# Altium schematic sheet ESP32-HWE-Dev-I2C.SchDoc(ESP32-HWE-Dev-I2C)
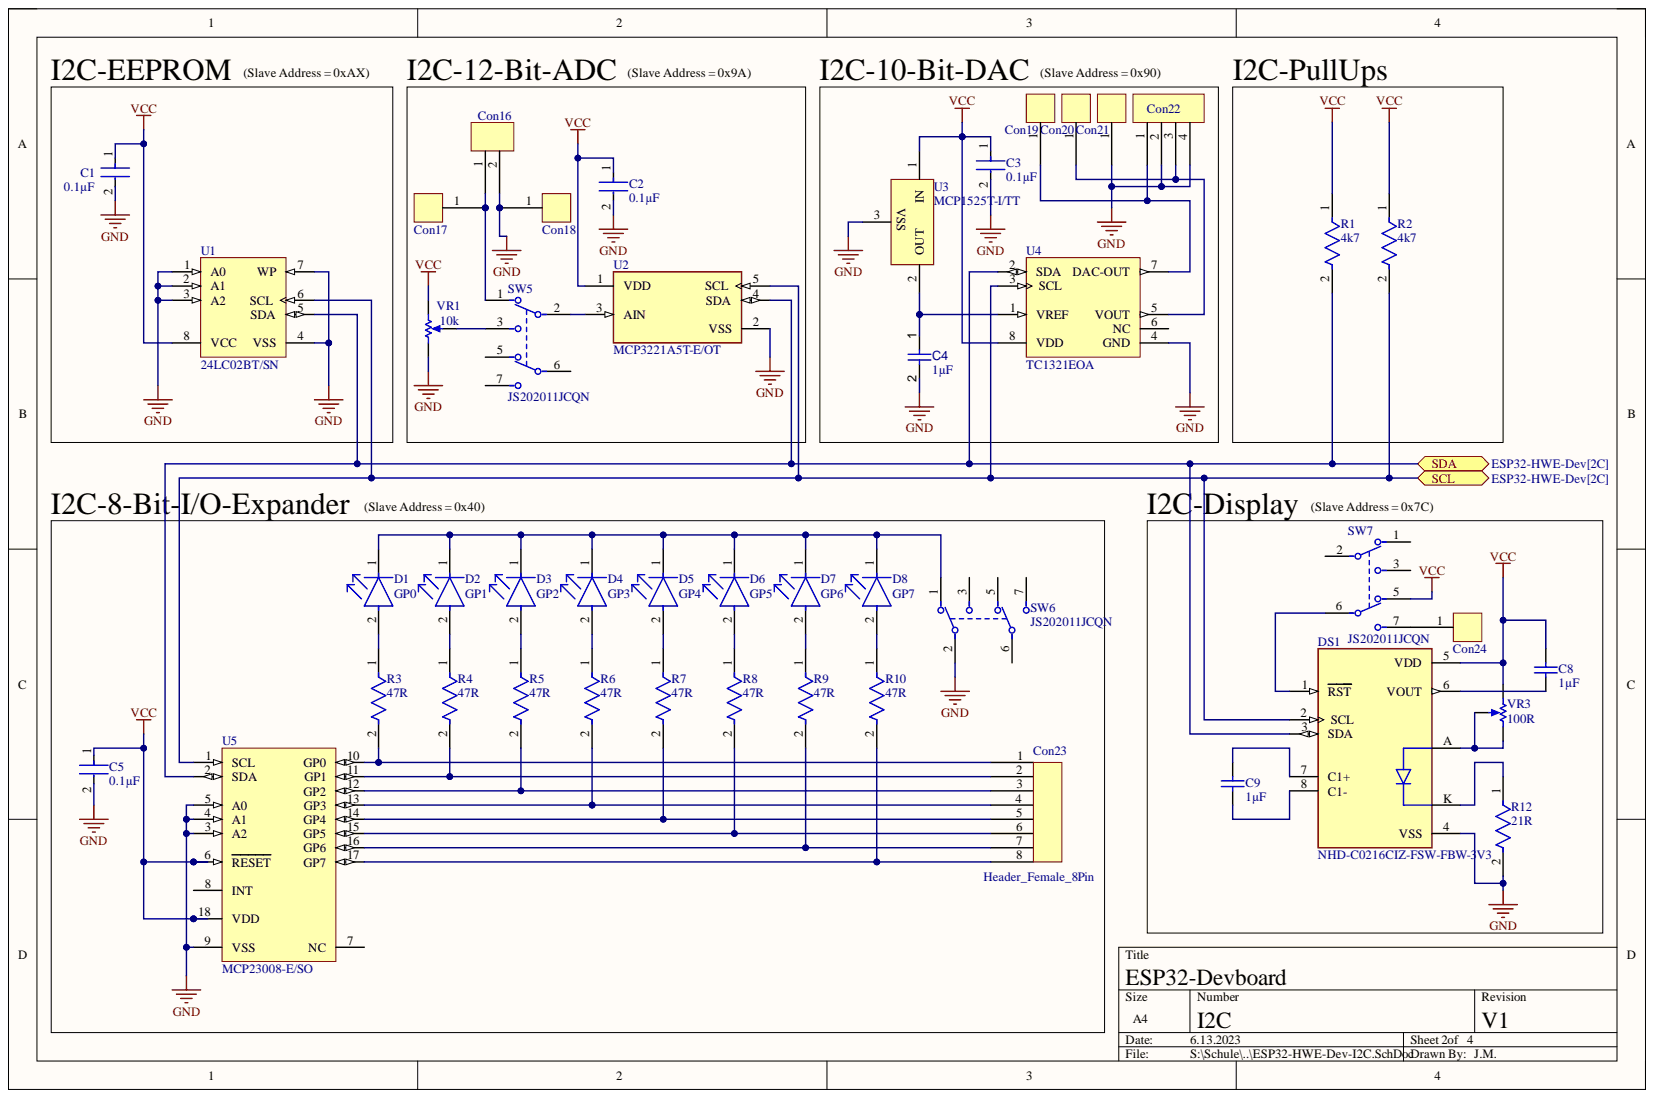
[diagram p0: ESP32-HWE-Dev-I2C.SchDoc]

## Parts
C1  0.1µF  [FP-0805-L_2_01_0_2-W_1_25-IPC_C]
C2  0.1µF  [FP-0805-L_2_01_0_2-W_1_25-IPC_C]
C3  0.1µF  [FP-0805-L_2_01_0_2-W_1_25-IPC_C]
C4  value 1µF  [CAPC2013X135X45NL10T25]  (hidden comment: Capacitor 1 uF +/- 10% 50 V 0805)
C5  0.1µF  [FP-0805-L_2_01_0_2-W_1_25-IPC_C]
C8  1µF  [CAPC2013X145X50LL20T25]
C9  1µF  [CAPC2013X145X50LL20T25]
Con16  Female 2 Pin  [Header_Female_2Pin]  (value not drawn)
Con17  Solder Nail RTM 1.3  [Solder_Nail_RTM_1.3]  (value not drawn)
Con18  Solder Nail RTM 1.3  [Solder_Nail_RTM_1.3]  (value not drawn)
Con19  I2C-DAC-DAC-Out  [Solder_Nail_RTM_1.3]  (value not drawn)
Con20  I2C-DAC-Vout  [Solder_Nail_RTM_1.3]  (value not drawn)
Con21  DAC-GND  [Solder_Nail_RTM_1.3]  (value not drawn)
Con22  Female 4 Pin  [Header_Female_4Pin]  (value not drawn)
Con23  Header_Female_8Pin  [Header_Female_8Pin]
Con24  Solder Nail RTM 1.3  [Solder_Nail_RTM_1.3]  (value not drawn)
D1  GP0  [FP-SML-LXT0805IW-TR-MFG]
D2  GP1  [FP-SML-LXT0805IW-TR-MFG]
D3  GP2  [FP-SML-LXT0805IW-TR-MFG]
D4  GP3  [FP-SML-LXT0805IW-TR-MFG]
D5  GP4  [FP-SML-LXT0805IW-TR-MFG]
D6  GP5  [FP-SML-LXT0805IW-TR-MFG]
D7  GP6  [FP-SML-LXT0805IW-TR-MFG]
D8  GP7  [FP-SML-LXT0805IW-TR-MFG]
DS1  NHD-C0216CIZ-FSW-FBW-3V3  [LCD_NHD-C0216CIZ-FSW-FBW-3V3]
R1  4k7  [FP-AC0805-MFG]
R2  4k7  [FP-AC0805-MFG]
R3  47R  [FP-AC0805-MFG]
R4  47R  [FP-AC0805-MFG]
R5  47R  [FP-AC0805-MFG]
R6  47R  [FP-AC0805-MFG]
R7  47R  [FP-AC0805-MFG]
R8  47R  [FP-AC0805-MFG]
R9  47R  [FP-AC0805-MFG]
R10  47R  [FP-AC0805-MFG]
R12  21R  [FP-AC0805-MFG]
SW5  JS202011JCQN  [FP-JS202011JCQN-MFG]
SW6  JS202011JCQN  [FP-JS202011JCQN-MFG]
SW7  JS202011JCQN  [FP-JS202011JCQN-MFG]
U1  24LC02BT/SN  [SOIC-SN8_N]
U2  MCP3221A5T-E/OT  [FP-C04-091-OT-IPC_A]
U3  MCP1525T-I/TT  [SOT-23-TT3_M]
U4  TC1321EOA  [SOIC-OA8_L]
U5  MCP23008-E/SO  [SOIC-SO18_N]
VR1  10k  [BOUR-3362P]
VR3  100R  [BOUR-3362P]

## Ports
SCL, SDA

## Nets
GND: C1.2 C2.2 C3.2 C4.2 C5.2 Con16.2 Con18.1 Con21.1 Con22.2 Con22.4 DS1.4(VSS) R12.2 SW6.2 U1.1(A0) U1.2(A1) U1.3(A2) U1.4(VSS) U1.7(WP) U2.2(VSS) U3.3 U4.4(GND) U5.3(A2) U5.4(A1) U5.5(A0) U5.9(VSS) VR1.1
VCC: C1.1 C2.1 C3.1 C5.1 C8.2 DS1.5(VDD) R1.1 R2.1 SW7.5 U1.8(VCC) U2.1(VDD) U3.1 U4.8(VDD) U5.6(RESET) U5.18(VDD) VR1.3 VR3.1
SCL: DS1.2(SCL) R2.2 U1.6(SCL) U2.5(SCL) U4.3(SCL) U5.1(SCL) ; port SCL
SDA: DS1.3(SDA) R1.2 U1.5(SDA) U2.4(SDA) U4.2(SDA) U5.2(SDA) ; port SDA
NetC4_1: C4.1 U3.2 U4.1(VREF)
NetC8_1: C8.1 DS1.6(VOUT)
NetC9_1: C9.1 DS1.8(C1-)
NetC9_2: C9.2 DS1.7(C1+)
NetCon16_1: Con16.1 Con17.1 SW5.1
NetCon19_1: Con19.1 Con22.1 U4.7(DAC-OUT)
NetCon20_1: Con20.1 Con22.3 U4.5(VOUT)
NetCon23_1: Con23.1 R3.2 U5.10(GP0)
NetCon23_2: Con23.2 R4.2 U5.11(GP1)
NetCon23_3: Con23.3 R5.2 U5.12(GP2)
NetCon23_4: Con23.4 R6.2 U5.13(GP3)
NetCon23_5: Con23.5 R7.2 U5.14(GP4)
NetCon23_6: Con23.6 R8.2 U5.15(GP5)
NetCon23_7: Con23.7 R9.2 U5.16(GP6)
NetCon23_8: Con23.8 R10.2 U5.17(GP7)
NetCon24_1: Con24.1 SW7.7
NetD1_1: D1.1 D2.1 D3.1 D4.1 D5.1 D6.1 D7.1 D8.1 SW6.1
NetD1_2: D1.2 R3.1
NetD2_2: D2.2 R4.1
NetD3_2: D3.2 R5.1
NetD4_2: D4.2 R6.1
NetD5_2: D5.2 R7.1
NetD6_2: D6.2 R8.1
NetD7_2: D7.2 R9.1
NetD8_2: D8.2 R10.1
NetDS1_1: DS1.1(RST) SW7.6
NetDS1_A: DS1.A VR3.2 VR3.3
NetDS1_K: DS1.K R12.1
NetSW5_2: SW5.2 U2.3(AIN)
NetSW5_3: SW5.3 VR1.2

Not connected: SW5 5 6 7; SW6 3 5 6 7; SW7 1 2 3; U4 6(NC); U5 7(NC) 8(INT)

## Library data of the parts
C1-C3 C5 = CMP-2000-05016-2: General Purpose Ceramic Capacitor, 0805, 100nF, 10%, X7R, 0.15, 50V; Dissipation Factor: 2.5%; Insulation Resistance: 100GR; Capacitance: 100nF; Case Code (Imperial): 0805; Case Code (Metric): 2012; Case/Package: 0805; Component Kind: Standard; Depth: 1.25mm; Dielectric: X7R; Dielectric Material: Ceramic; Height: 0.5mm; Lead Free: Lead Free; Length: 2mm; Library Name: Altium Content Vault; Max Operating Temperature: 125°C; Min Operating Temperature: -55°C; Mount: Surface Mount; Number of Pins: 2; Package Quantity: 4000; Packaging: Cut Tape; Radiation Hardening: No; REACH SVHC: No SVHC; RoHS Compliant: Yes; Series: MLCC X7R; Termination: Standard; Thickness: 0.037inch; Tolerance: 10%; Voltage: 50V; Voltage Rating: 50V; Voltage Rating (DC): 50V; Weight: 0.001411oz; Width: 1.2mm
C4 = CMP-001-00007-6: Chip Capacitor, 1 uF, +/- 10%, 50 V, 0805 (2012 Metric); Capacitor Type: Ceramic; Voltage Rating: 50V; Case/Package: 0805; Component Kind: Standard; Max Operating Temperature: 125°C; Min Operating Temperature: -55°C; Mounting Technology: SM; Pins: 2; RoHS Compliant: True; Tolerance: 10%; Library Name: Jakob Metzler
C8 C9 = CMP-1036-04746-2: Manufacturer: Kemet; Part Number: C0805C105K4PACTU; Component Kind: Standard; Library Name: Altium Content Vault
Con16 = Header_Female_2Pin: Component Kind: Standard; Library Name: ESP32-HWE-Dev.SchLib
Con17-Con21 Con24 = Solder_Nail_RTM_1.3: Component Kind: Standard; Library Name: ESP32-HWE-Dev.SchLib
Con22 = Header_Female_4Pin: Component Kind: Standard; Library Name: ESP32-HWE-Dev.SchLib
D1-D8 = CMP-1724-00002-2: LED RED DIFFUSED SMD; Approvals: UL; Dominant Wavelength: 635nm; Forward Current: 20mA; Forward Voltage: 2V; Lens Color: White; Lens Style: Rectangular; Luminous Intensity: 11mcd; Number of LEDs: 1; Viewing Angle: 140°; Case Code (Imperial): 0805; Case Code (Metric): 2012; Case/Package: 0805; Color: Red; Component Kind: Standard; Height: 0.8mm; Illumination Color: Red; Lead Free: Lead Free; Length: 2mm; Lens Transparency: Diffused; Library Name: Altium Content Vault; Max Operating Temperature: 80°C; Min Operating Temperature: -25°C; Mount: Surface Mount; Number of Pins: 2; Package Quantity: 1; Packaging: Tape and Reel; REACH SVHC: No SVHC; Test Current: 20mA; Wavelength: 635nm; Width: 1.25mm
DS1 = NHD-C0216CIZ-FSW-FBW-3V3: Character Display FSTN Transflective 16Char x 2Line 8-Pin; MF: {'manufacturer': u'Newhaven'}; Availability: Unavailable; Component Kind: Standard; ComponentLink1Description: Datasheet; ComponentLink1URL: https://s3.amazonaws.com/snapeda/datasheet/NHD-C0216CIZ-FSW-FBW-3V3_Newhaven.pdf; MP: NHD-C0216CIZ-FSW-FBW-3V3; Package: None; Price: None
R1-R10 R12 = CMP-2001-04476-2: RES SMD 4.7K OHM 5% 1/8W 0805; Manufacturer: Yageo; Manufacturer Part Number: AC0805JR-074K7L; Automotive Grade: Grade 0; Resistance: 4.7KΩ; Tolerance: 0.05; Value: 4.7KΩ; Voltage Rating: 150V; Automotive: Yes; Category: Res; Component Kind: Standard; ComponentLink2Description: Datasheet; ComponentLink2URL: http://www.yageo.com/documents/recent/PYu-AC_51_RoHS_L_6.pdf; Composition: Thick Film; Device Class L1: Passive Components; Device Class L2: Resistors; Device Class L3: Chip SMD Resistors; Digikey Description: RES SMD 4.7K OHM 5% 1/8W 0805; Footprint Url: http://www.yageo.com/exep/pages/download/literatures/PYu-R_Mount_10.pdf; Height: 0.6mm; Ipc Land Pattern Name: RESC200125X50; Lead Free: Yes; Library Name: Altium Content Vault; Mouser Description: Thick Film Resistors - SMD 1/8W 4.7K ohm 5% AEC-Q200; Octopart: https://octopart.com/ac0805jr-074k7l-yageo-26638632; Package: AC0805; Power Rating: 1/8W; RoHS: TRUE; Series: AC; Temperature: -55°C to +155°C; Temperature Range High: +155°C; Temperature Range Low: -55°C
SW5-SW7 = CMP-10038-000009-1: SWITCH SLIDE DPDT 300MA 6V; Actuator Length: 2mm; Actuator Material: Nylon; Actuator Type: Standard; Electrical Life: 5000Cycles; Switch Function: On-On; Component Kind: Standard; Contact Material: Copper Alloy; Contact Plating: Silver; Current Rating: 300mA; Library Name: Altium Content Vault; Max Operating Temperature: 85°C; Min Operating Temperature: -40°C; Mount: Surface Mount; Packaging: Cut Tape; Switch Travel: 2mm; Throw Configuration: DPDT; Voltage Rating (DC): 6V
U1 = CMP-0159-00324-1: 2Kbit, 400kHz, 2.5V, I2C Serial EEPROM, 8-Pin SOIC 150mil, Commercial Temperature, Tape and Reel; Code_IPC: SOIC127P600-8; Code_JEDEC: MS-012; Component Kind: Standard; DatasheetVersion: revJ, Feb-2009; Library Name: Altium Content Vault; PackageDescription: 8-Lead Plastic Small Outline (SN) - Narrow, 3.90mm Body [SOIC]; PackageReference: SOIC-SN8; PackageVersion: revBB, Aug-2009
U2 = CMP-0188-00729-3: IC ADC 12BIT SAR SOT23-5; Conversion Rate: 22.3KS/s; Integral Nonlinearity (INL): 2LSB; Interface: I2C; Max Supply Voltage: 5.5V; Min Supply Voltage: 2.7V; Nominal Supply Current: 175uA; Number of A/D Converters: 1; Number of Bits: 12; Polarity: Unipolar; Resolution: 12b; Case/Package: SOT-23; Component Kind: Standard; Height: 1.3mm; Lead Free: Lead Free; Length: 3.1mm; Library Name: Altium Content Vault; Max Operating Temperature: 125°C; Min Operating Temperature: -40°C; Mount: Surface Mount; Number of Analog Inputs: 1; Number of Channels: 1; Number of Converters: 1; Number of Elements: 1; Number of Inputs: 1; Number of Pins: 5; Package Quantity: 3000; Packaging: Tape and Reel; Radiation Hardening: No; REACH SVHC: No SVHC; Sampling Rate: 22.3KS/s; Termination: SMD/SMT; Width: 1.8mm
U3 = CMP-0131-00003-1: 2.5V Voltage Reference, 3-Pin SOT-23, Industrial Temperature, Tape and Reel; Code_IPC: SOT23-3; Component Kind: Standard; DatasheetVersion: revB, Feb-2005; Library Name: Altium Content Vault; PackageDescription: 3-Lead Plastic Small Outline Transistor (TT) [SOT-23]; PackageReference: SOT-23-TT3; PackageVersion: revBB, Aug-2009
U4 = CMP-0188-00049-2: 10-Bit Digital-to-Analog Converter with Two-Wire Interface, 8-Pin SOIC 150mil, Extended Temperature; Code_IPC: SOIC127P600-8; Component Kind: Standard; ComponentLink1Description: Manufacturer URL; ComponentLink1URL: http://www.microchip.com/; ComponentLink2Description: Datasheet; ComponentLink2URL: http://ww1.microchip.com/downloads/en/DeviceDoc/21387C.pdf; ComponentLink3Description: Package Specification; ComponentLink3URL: http://www.microchip.com/stellent/groups/techpub_sg/documents/packagingspec/en012702.pdf; DatasheetVersion: revC, Nov-2010; Library Name: Altium Content Vault; PackageDescription: 8-Lead Plastic Small Outline (OA) - Narrow, 3.90mm Body [SOIC]; PackageReference: SOIC-OA8; PackageVersion: revBB, Aug-2009; RevisionNote: URLs added. DatasheetVersion updated.
U5 = CMP-0186-00050-2: 8-Bit I/O Expander with Serial Interface, 18-Pin SOIC, Extended Temperature; Code_JEDEC: MS-013; Component Kind: Standard; ComponentLink1Description: Manufacturer URL; ComponentLink1URL: http://www.microchip.com/; ComponentLink2Description: Datasheet; ComponentLink2URL: http://ww1.microchip.com/downloads/en/DeviceDoc/21919e.pdf; ComponentLink3Description: Package Specification; ComponentLink3URL: http://www.microchip.com/stellent/groups/techpub_sg/documents/packagingspec/en012702.pdf; DatasheetVersion: revE, Aug-2007; Library Name: Altium Content Vault; PackageDescription: 18-Lead Plastic Small Outline (SO) - Wide 7.50mm Body [SOIC]; PackageReference: SOIC-SO18; PackageVersion: revBB, Aug-2009; RevisionNote: URLs added.
VR1 VR3 = CMP-1747-00004-1: Square Trimpot(R) Trimming Potentiometer, 10 KOhm, 300 V, -55 to 125 degC, 3-Pin THD, RoHS, Tube; Power Rating: 1 W; Resistance: 50 mohm; Tolerance: 1%; Voltage Rating: 220 mV; Case Code (Imperial): 0612; Case Code (Metric): 1632; Case/Package: 1206; Component Kind: Standard; ComponentLink1Description: Manufacturer URL; ComponentLink1URL: http://www.bourns.com/; ComponentLink2Description: Datasheet; ComponentLink2URL: http://www.bourns.com/docs/Product-Datasheets/3362.pdf; DatasheetVersion: May-16; Height: 0.6 mm; Length: 3.2 mm; Library Name: Altium Content Vault; Max Operating Temperature: 125degC; Min Operating Temperature: -25degC; Mount: Surface Mount; Package Quantity: 5000; PackageDescription: 3-Pin Through Hole Device, Body 6.6 x 6.99 mm, Pitch 2.54 mm; PackageReference: 3326P; Packaging: Tape and Reel; Pins: 2; REACH SVHC: No SVHC; RoHSCompliant: True; Width: 1.6 mm
Con23 = Header_Female_8Pin: Component Kind: Standard; Library Name: ESP32-HWE-Dev.SchLib
